# Altium schematic sheet Sheet1.SchDoc
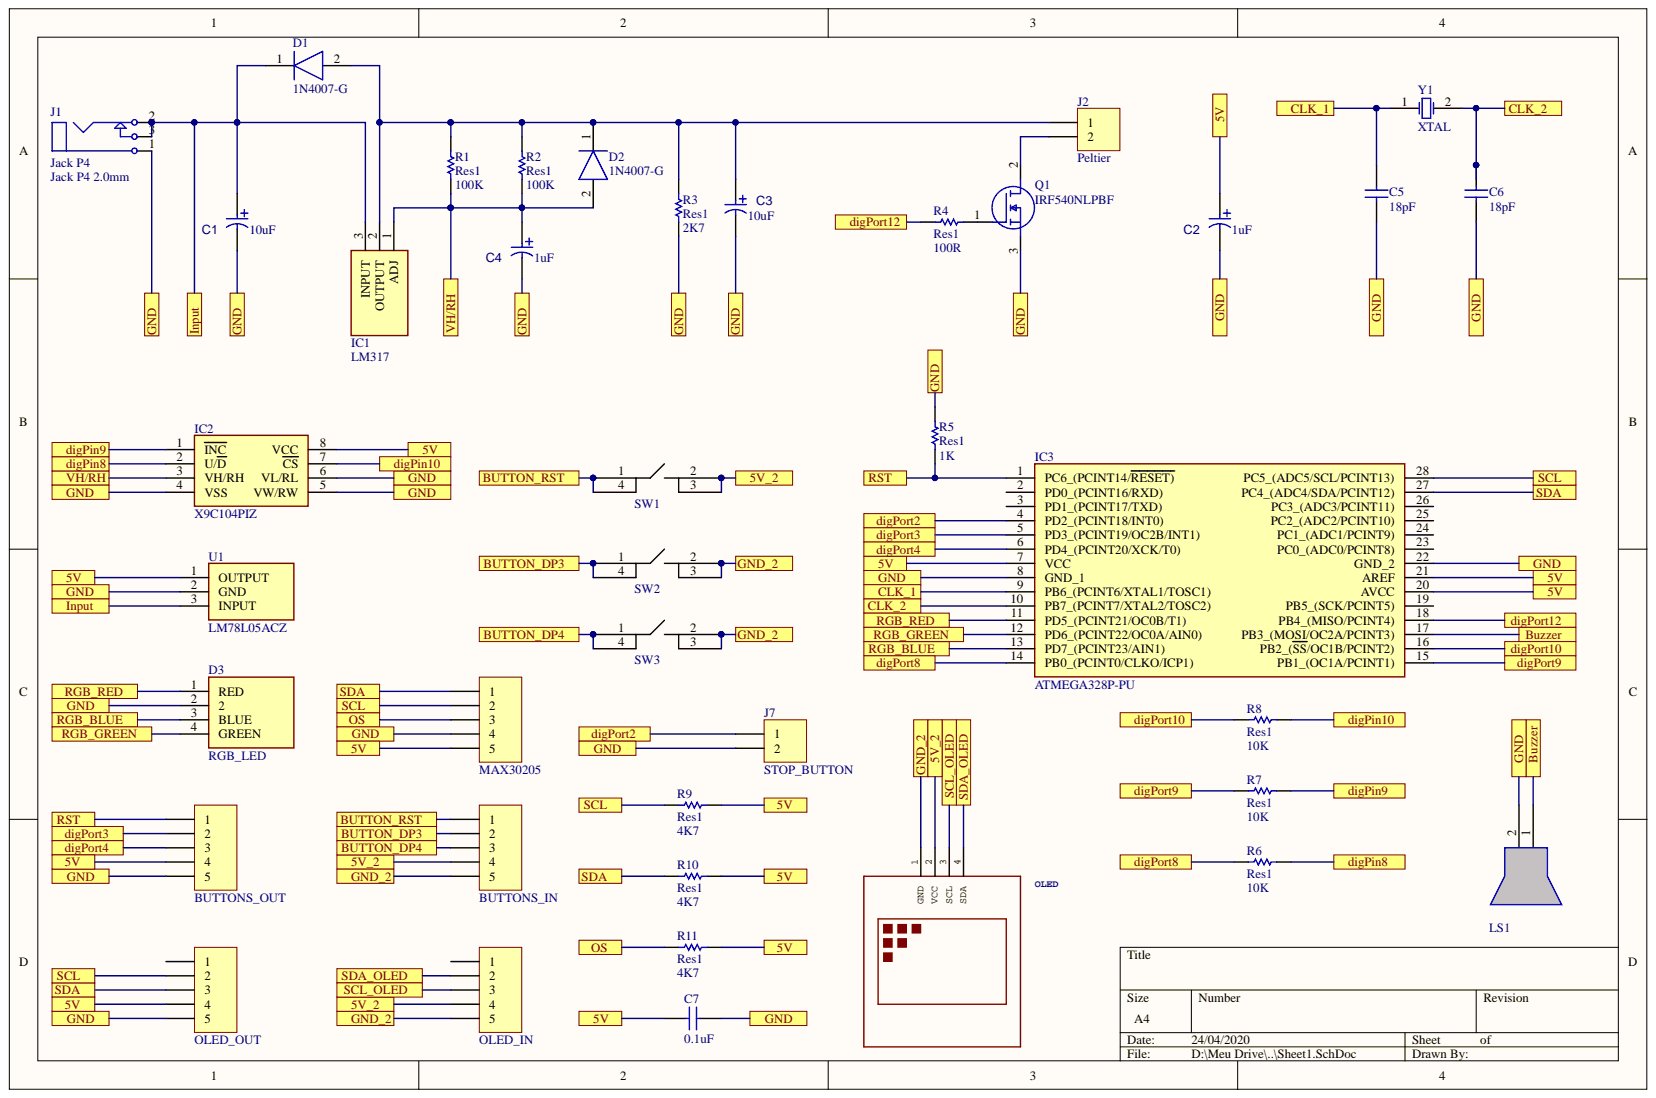
[diagram p0: Sheet1.SchDoc]

## Parts
BUTTONS_IN  XH2.54-5P  [XH2.54-5]  (value not drawn)
BUTTONS_OUT  XH2.54-5P  [XH2.54-5]  (value not drawn)
C1  Valor: 10uF  [CAPACITOR ELETROLITICO 5x11x2.54mm]  (hidden comment: Capacitor Eletrolitico)
C2  Valor: 1uF  [CAPACITOR ELETROLITICO 4x5x1.6mm]  (hidden comment: Capacitor Eletrolitico)
C3  Valor: 10uF  [CAPACITOR ELETROLITICO 5x11x2.54mm]  (hidden comment: Capacitor Eletrolitico)
C4  Valor: 1uF  [CAPACITOR ELETROLITICO 4x5x1.6mm]  (hidden comment: Capacitor Eletrolitico)
C5  Valor: 18pF  [Capacitor Ceramico]  (hidden comment: Capacitor Ceramico)
C6  Valor: 18pF  [Capacitor Ceramico]  (hidden comment: Capacitor Ceramico)
C7  Valor: 0.1uF  [Capacitor Ceramico]  (hidden comment: Capacitor Ceramico)
D1  1N4007-G  [DIOAD1410W90L470D235]
D2  1N4007-G  [DIOAD1410W90L470D235]
D3  RGB_LED  [KNIGHTBRIGHT-1.27PITCH-4PIN]
IC1  LM317  [TO254P467X1016X1971-3P]
IC2  X9C104PIZ  [DIP794W51P254L952H533Q8N]
IC3  ATMEGA328P-PU  [DIP794W53P254L3467H457Q28N]
J1  Jack P4  Valor: Jack P4 2.0mm  [CONECTOR_JACK_P4]
J2  Peltier  [KF301-5.08-2P]
J7  STOP_BUTTON  [KF301-5.08-2P]
LS1  BUZZER  [Buzzer]  (value not drawn)
MAX30205  XH2.54-5P  [XH2.54-5]  (value not drawn)
OLED  128x64 Dot Matrix OLED Module based on SSD1306 chipVariant with I2C interfaceMore details available here:http://www.instructables.com/id/Monochrome-096-i2c-OLED-display-with-arduino-SSD13/SSD1306 datasheet:https://cdn-shop.adafruit.com/datasheets/SSD1306.pdfClick here to find device on ebay.comNote: There are two variants: I2C and SPI. Search for the proper version.  [DISPLAY-OLED-128X64-I2C]  (value not drawn)
OLED_IN  XH2.54-5P  [XH2.54-5]  (value not drawn)
OLED_OUT  XH2.54-5P  [XH2.54-5]  (value not drawn)
Q1  IRF540NLPBF  [TO254P483X1016X1501-3P]
R1  Res1  value 100K  [AXIAL-0.3]
R2  Res1  value 100K  [AXIAL-0.3]
R3  Res1  value 2K7  [AXIAL-0.3]
R4  Res1  value 100R  [AXIAL-0.3]
R5  Res1  value 1K  [AXIAL-0.3]
R6  Res1  value 10K  [AXIAL-0.3]
R7  Res1  value 10K  [AXIAL-0.3]
R8  Res1  value 10K  [AXIAL-0.3]
R9  Res1  value 4K7  [AXIAL-0.3]
R10  Res1  value 4K7  [AXIAL-0.3]
R11  Res1  value 4K7  [AXIAL-0.3]
SW1  Chave Tact  [Chave TACT]  (value not drawn)
SW2  Chave Tact  [Chave TACT]  (value not drawn)
SW3  Chave Tact  [Chave TACT]  (value not drawn)
U1  LM78L05ACZ  [TO260P419X476X877-3P]
Y1  XTAL  [R38]

## Ports
5V, 5V_2, BUTTON_DP3, BUTTON_DP4, BUTTON_RST, Buzzer, CLK_1, CLK_2, digPin8, digPin9, digPin10, digPort2, digPort3, digPort4, digPort8, digPort9, digPort10, digPort12, GND, GND_2, Input, OS, RGB_BLUE, RGB_GREEN, RGB_RED, RST, SCL, SCL_OLED, SDA, SDA_OLED, VH/RH

## Nets
5V: BUTTONS_OUT.4 C2.1 C7.2 IC2.8(VCC) IC3.7(VCC) IC3.20(AVCC) IC3.21(AREF) MAX30205.5 OLED_OUT.4 R9.1 R10.1 R11.1 U1.1 ; port 5V
5V_2: BUTTONS_IN.4 OLED.2(VCC) OLED_IN.4 SW1.2 SW1.3 ; port 5V_2
BUTTON_DP3: BUTTONS_IN.2 SW2.1 SW2.4 ; port BUTTON_DP3
BUTTON_DP4: BUTTONS_IN.3 SW3.1 SW3.4 ; port BUTTON_DP4
BUTTON_RST: BUTTONS_IN.1 SW1.1 SW1.4 ; port BUTTON_RST
Buzzer: IC3.17(PB3_(MOSI/OC2A/PCINT3)) LS1.1 ; port Buzzer
CLK_1: C5.2 IC3.9(PB6_(PCINT6/XTAL1/TOSC1)) Y1.1 ; port CLK_1
CLK_2: C6.2 IC3.10(PB7_(PCINT7/XTAL2/TOSC2)) Y1.2 ; port CLK_2
digPin8: IC2.2(U/D) R6.1 ; port digPin8
digPin9: IC2.1(INC) R7.1 ; port digPin9
digPin10: IC2.7(CS) R8.1 ; port digPin10
digPort2: IC3.4(PD2_(PCINT18/INT0)) J7.1 ; port digPort2
digPort3: BUTTONS_OUT.2 IC3.5(PD3_(PCINT19/OC2B/INT1)) ; port digPort3
digPort4: BUTTONS_OUT.3 IC3.6(PD4_(PCINT20/XCK/T0)) ; port digPort4
digPort8: IC3.14(PB0_(PCINT0/CLKO/ICP1)) R6.2 ; port digPort8
digPort9: IC3.15(PB1_(OC1A/PCINT1)) R7.2 ; port digPort9
digPort10: IC3.16(PB2_(SS/OC1B/PCINT2)) R8.2 ; port digPort10
digPort12: IC3.18(PB4_(MISO/PCINT4)) R4.2 ; port digPort12
GND: BUTTONS_OUT.5 C1.2 C2.2 C3.2 C4.2 C5.1 C6.1 C7.1 D3.2 IC2.4(VSS) IC2.5(VW/RW) IC2.6(VL/RL) IC3.8(GND_1) IC3.22(GND_2) J1.1 J7.2 LS1.2 MAX30205.4 OLED_OUT.5 Q1.3 R3.1 R5.1 U1.2 ; port GND
GND_2: BUTTONS_IN.5 OLED.1(GND) OLED_IN.5 SW2.2 SW2.3 SW3.2 SW3.3 ; port GND_2
Input: C1.1 D1.1 IC1.3 J1.2 J1.3 U1.3 ; port Input
OS: MAX30205.3 R11.2 ; port OS
RGB_BLUE: D3.3(BLUE) IC3.13(PD7_(PCINT23/AIN1)) ; port RGB_BLUE
RGB_GREEN: D3.4(GREEN) IC3.12(PD6_(PCINT22/OC0A/AIN0)) ; port RGB_GREEN
RGB_RED: D3.1(RED) IC3.11(PD5_(PCINT21/OC0B/T1)) ; port RGB_RED
RST: BUTTONS_OUT.1 IC3.1(PC6_(PCINT14/RESET)) R5.2 ; port RST
SCL: IC3.28(PC5_(ADC5/SCL/PCINT13)) MAX30205.2 OLED_OUT.2 R9.2 ; port SCL
SCL_OLED: OLED.3(SCL) OLED_IN.3 ; port SCL_OLED
SDA: IC3.27(PC4_(ADC4/SDA/PCINT12)) MAX30205.1 OLED_OUT.3 R10.2 ; port SDA
SDA_OLED: OLED.4(SDA) OLED_IN.2 ; port SDA_OLED
VH/RH: C4.1 D2.2 IC1.1 IC2.3(VH/RH) R1.1 R2.1 ; port VH/RH
NetC3_1: C3.1 D1.2 D2.1 IC1.2 J2.1 R1.2 R2.2 R3.2
NetJ2_2: J2.2 Q1.2
NetQ1_1: Q1.1 R4.1

Not connected: IC3 2(PD0_(PCINT16/RXD)) 3(PD1_(PCINT17/TXD)) 19(PB5_(SCK/PCINT5)) 23(PC0_(ADC0/PCINT8)) 24(PC1_(ADC1/PCINT9)) 25(PC2_(ADC2/PCINT10)) 26(PC3_(ADC3/PCINT11)); OLED_IN 1; OLED_OUT 1

## Library data of the parts
BUTTONS_IN BUTTONS_OUT MAX30205 OLED_IN OLED_OUT = XH2.54-5P: 通用连接端子; Component Kind: Standard; Library Name: AD_Connectors.SchLib
C1-C4 = Capacitor Eletrolitico: Descrição: Capacitor Eletrolitico PTH; Encapsulamento: PTH Radia Xmm x Ymm; Component Kind: Standard; Description: Capacitor Eletrolitico; Library Name: Altium_Library_Brasil.IntLib
C5-C7 = Capacitor Ceramico: Capacitor PTH; Component Kind: Standard; Descrição: Capacitor Ceramico; Encapsulamento: PTH; Library Name: Altium_Library_Brasil.IntLib
D1 D2 = 1N4007-G: Diode; Manufacturer_Name: Comchip Technology; Allied_Number: 70426645; Allied Price/Stock: https://www.alliedelec.com/comchip-technology-1n4007-g/70426645/; Component Kind: Standard; Datasheet Link: http://www.comchiptech.com/cms/UserFiles/QW-BG013%201N4001%20Thru456224.%201N4007%20REV.A.pdf; Manufacturer_Part_Number: 1N4007-G; Mouser Part Number: 750-1N4007-G; Mouser Price/Stock: https://www.mouser.com/Search/Refine.aspx?Keyword=750-1N4007-G
D3 = L-154A4SURKQBDZGW: Diode; Manufacturer_Name: Kingbright; Component Kind: Standard; Datasheet Link: http://docs-emea.rs-online.com/webdocs/139d/0900766b8139d70e.pdf; Manufacturer_Part_Number: L-154A4SURKQBDZGW; Mouser Part Number: N/A; Mouser Price/Stock: https://www.mouser.com/Search/Refine.aspx?Keyword=N%2FA; RS Part Number: 8614307; RS Price/Stock: http://uk.rs-online.com/web/p/products/8614307
IC1 = LM317: Integrated Circuit; Manufacturer_Name: Changjiang Electronics Tech (CJ); Component Kind: Standard; Datasheet Link: https://datasheet.lcsc.com/szlcsc/Changjiang-Electronics-Tech-CJ-LM317_C22147.pdf; Height: 4.67mm; Manufacturer_Part_Number: LM317
IC2 = X9C104PIZ: Integrated Circuit; Manufacturer_Name: Renesas Electronics; Component Kind: Standard; Datasheet Link: https://www.arrow.com/en/products/x9c104piz/intersil; Height: 5.334mm; Manufacturer_Part_Number: X9C104PIZ; RS Part Number: 6424115P; RS Price/Stock: http://uk.rs-online.com/web/p/products/6424115P
IC3 = ATMEGA328P-PU: Integrated Circuit; Manufacturer_Name: Microchip; Allied_Number: 70332157; Allied Price/Stock: https://www.alliedelec.com/microchiptechnologyinc-atmega328p-pu/70332157/; Component Kind: Standard; Datasheet Link: http://ww1.microchip.com/downloads/en/DeviceDoc/Atmel-42735-8-bit-AVR-Microcontroller-ATmega328-328P_Datasheet.pdf; Height: 4.572mm; Manufacturer_Part_Number: ATMEGA328P-PU; Mouser Part Number: 556-ATMEGA328P-PU; Mouser Price/Stock: https://www.mouser.com/Search/Refine.aspx?Keyword=556-ATMEGA328P-PU; RS Part Number: 9222142P; RS Price/Stock: http://uk.rs-online.com/web/p/products/9222142P
J1 = Jack P4: Conector Femêa Jack P4; Descrição: Conector Femêa Jack P4 2mm; Encapsulamento: PTH; Component Kind: Standard; Library Name: Conectores_Sch.SchLib
J2 J7 = 接线端子-2P: 通用接线端子; Component Kind: Standard; Library Name: AD_Connectors.SchLib
LS1 = BUZZER: DC Buzzer; Descrição: BUZZER 5V; Encapsulamento: PTH; Component Kind: Standard; Library Name: Altium_Library_Brasil.IntLib
OLED = DISPLAY-OLED-128X64-I2C: GateName_1: G$1; Component Kind: Standard; DeviceSetName: DISPLAY-OLED-128X64-I2C; Library Name: Miscellaneous Connectors.IntLib; LibraryName: diy-modules; Package: DISPLAY-OLED-128X64-I2C; SymbolName_1: DISPLAY-OLED-128X64-I2C
Q1 = IRF540NLPBF: MOSFET (N-Channel); Manufacturer_Name: International Rectifier; Component Kind: Standard; Datasheet Link: https://www.infineon.com/dgdl/irf540nspbf.pdf?fileId=5546d462533600a4015355e3a72819a3; Height: 4.83mm; Manufacturer_Part_Number: IRF540NLPBF; Mouser Part Number: 942-IRF540NLPBF; Mouser Price/Stock: http://www.mouser.com/Search/ProductDetail.aspx?qs=9%252bKlkBgLFf0FQw5bWQHS0A%3d%3d
R1-R11 = Res1: Resistor; Component Kind: Standard; LatestRevisionDate: 17-Jul-2002; LatestRevisionNote: Re-released for DXP Platform.; Library Name: Miscellaneous Devices.IntLib; PackageDescription: Axial Device, Thru-Hole; 2 Leads; 0.3 in Pin Spacing; PackageReference: AXIAL-0.3; Published: 8-Jun-2000; Signal Integrity: Res; Simulation: RESISTOR; Publisher: Altium Limited
SW1-SW3 = Chave Tact: Descrição: Chave Tact 6x6x5mm; Encapsulamento: PTH; Component Kind: Standard; Description: Chave Tact; Library Name: Altium_Library_Brasil.IntLib
U1 = LM78L05ACZ: Undefined or Miscellaneous; Manufacturer_Name: ON Semiconductor; Component Kind: Standard; Datasheet Link: http://www.mouser.com/ds/2/149/LM78L05A-889520.pdf; Height: 4.19mm; Manufacturer_Part_Number: LM78L05ACZ; Mouser Part Number: 512-LM78L05ACZ; Mouser Price/Stock: https://www.mouser.com/Search/Refine.aspx?Keyword=512-LM78L05ACZ; RS Part Number: 7613549P; RS Price/Stock: http://uk.rs-online.com/web/p/products/7613549P
Y1 = XTAL: Crystal Oscillator; Component Kind: Standard; LatestRevisionDate: 17-Jul-2002; LatestRevisionNote: Re-released for DXP Platform.; Library Name: Miscellaneous Devices.IntLib; PackageDescription: Crystal, Thru-Hole; 2 Leads; Body 3.1 x 8.2 mm (Dia.xH); PackageReference: R38; Published: 8-Jun-2000; Simulation: XTAL; Publisher: Altium Limited
